# Altium schematic sheet 02_Top_Level.SchDoc
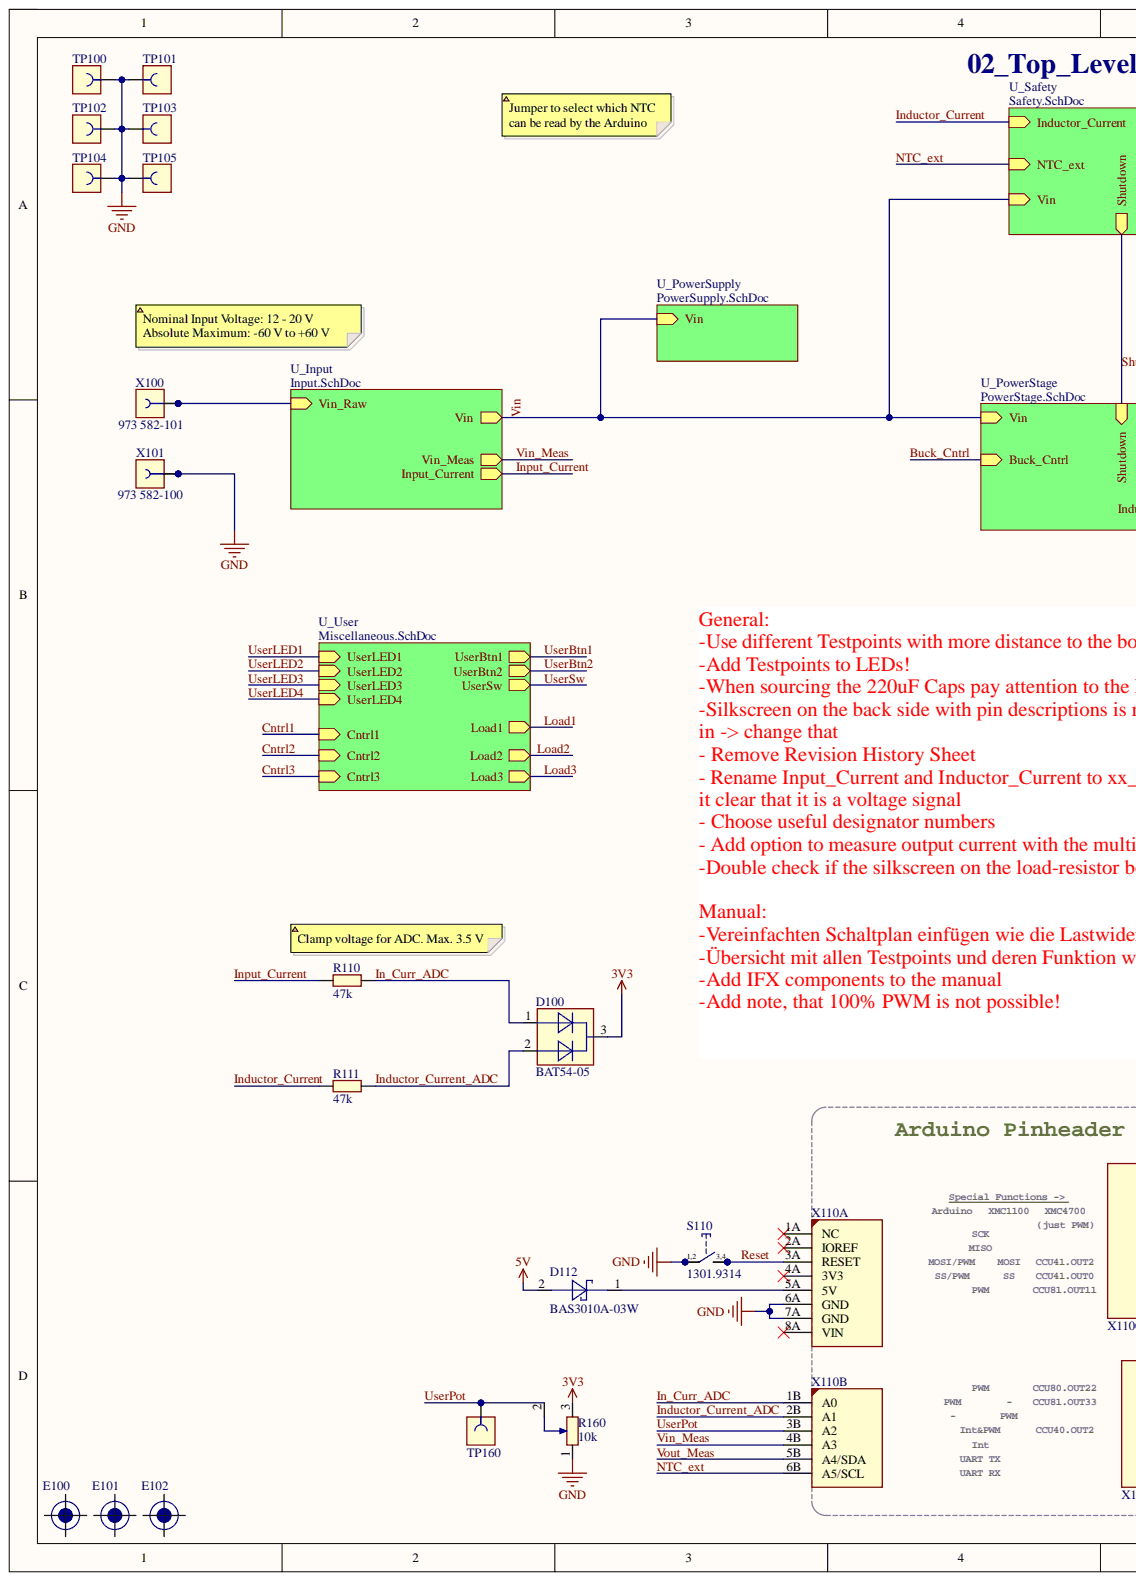
[diagram p0: 02_Top_Level.SchDoc, left]
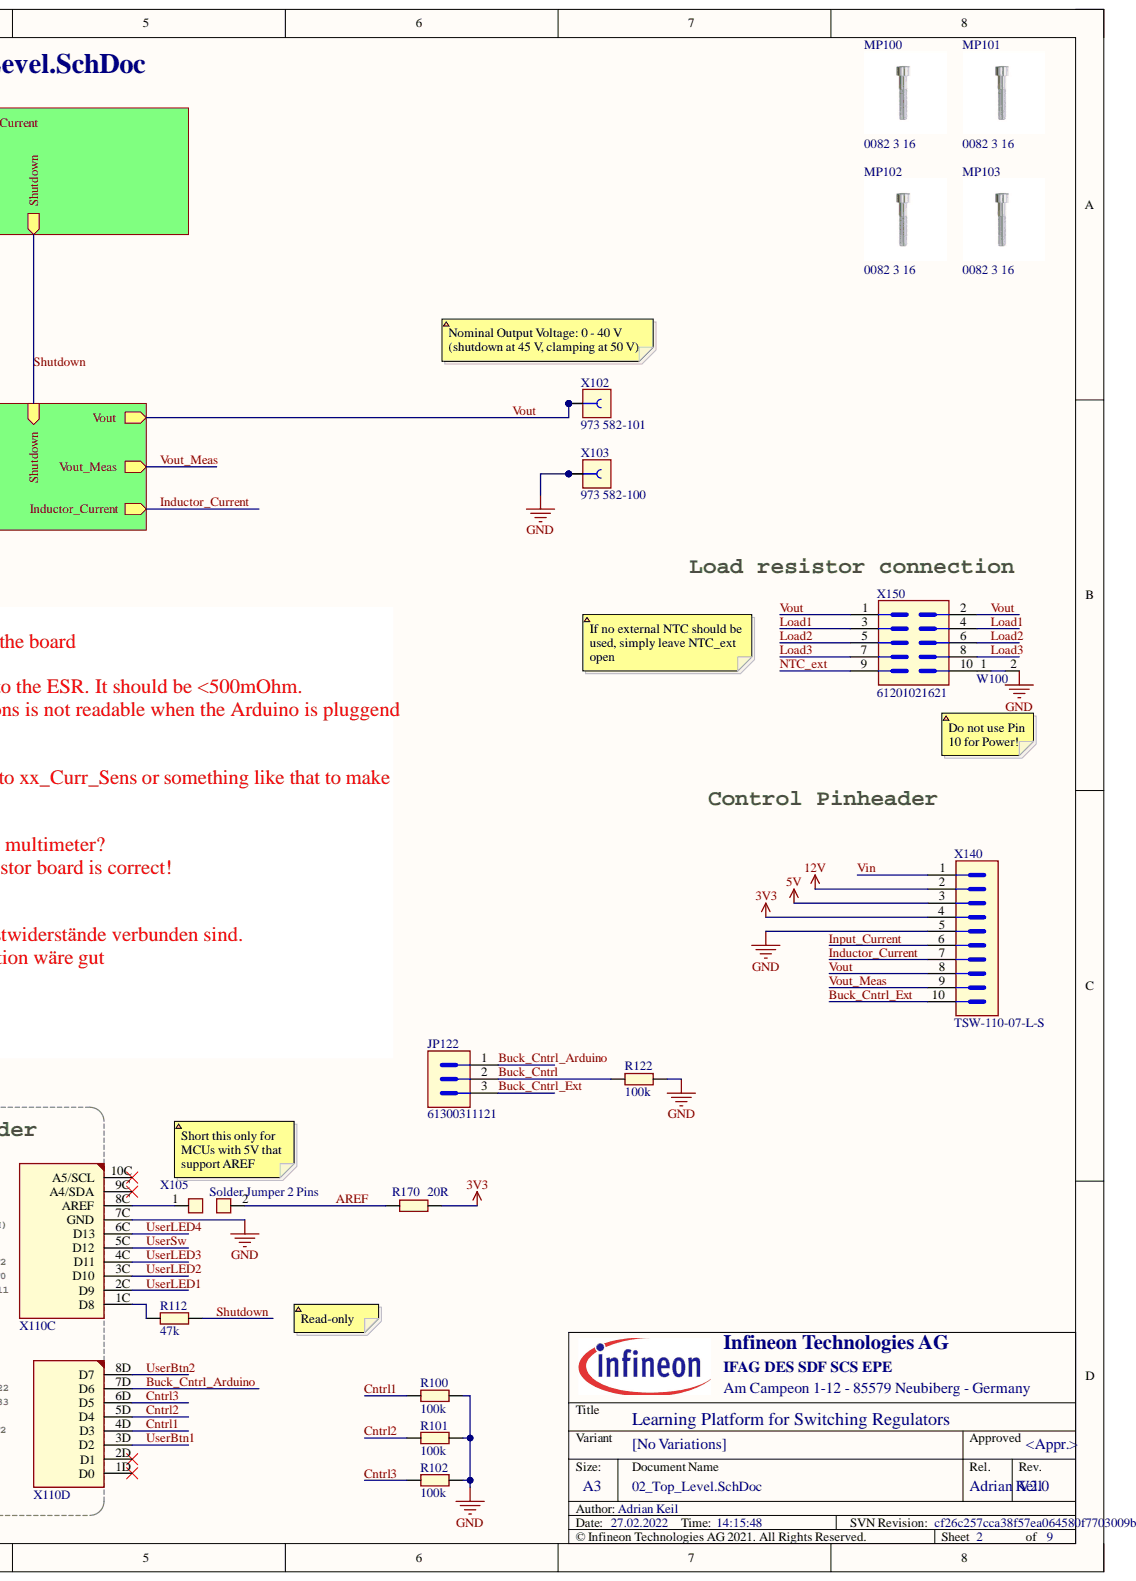
[diagram p1: 02_Top_Level.SchDoc, right]

## Parts
D100  BAT54-05  [SOT95P240X110-3N-1-V]
D112  BAS3010A-03W  [SOD2513X110N]
E100  (no value)  [fiducial]  (no BOM)
E101  (no value)  [fiducial]  (no BOM)
E102  (no value)  [fiducial]  (no BOM)
JP122  61300311121  [CON-M-THT-61300311121]
MP100  0082 3 16  [SCREW_0082 3 16_NPTH]
MP101  0082 3 16  [SCREW_0082 3 16_NPTH]
MP102  0082 3 16  [SCREW_0082 3 16_NPTH]
MP103  0082 3 16  [SCREW_0082 3 16_NPTH]
R100  100k  [RESC1609X50N]
R101  100k  [RESC1609X50N]
R102  100k  [RESC1609X50N]
R110  47k  [RESC1609X50N]
R111  47k  [RESC1609X50N]
R112  47k  [RESC1609X50N]
R122  100k  [RESC1609X50N]
R160  10k  [POT-SMD-3361P]
R170  20R  [RESC1609X50N]
S110  1301.9314  [SW-SMD-1301.9314]
TP100  5011  [CON-THT-TP-5011]  (value not drawn)
TP101  5011  [CON-THT-TP-5011]  (value not drawn)
TP102  5011  [CON-THT-TP-5011]  (value not drawn)
TP103  5011  [CON-THT-TP-5011]  (value not drawn)
TP104  5011  [CON-THT-TP-5011]  (value not drawn)
TP105  5011  [CON-THT-TP-5011]  (value not drawn)
TP160  S1751-46R  [CON-SMD-TT-S1751-46]  (value not drawn)
W100  Net_Tie_2  [Net_Tie_Small_2P]  (value not drawn)
X100  973 582-101  [CON-THT-973 582- 101]
X101  973 582-100  [CON-THT-973 582- 100]
X102  973 582-101  [CON-THT-973 582- 101]
X103  973 582-100  [CON-THT-973 582- 100]
X105  Solder Jumper 2 Pins  [SOLDER_BRIDGE_0.7X1.299PAD]
X110  Arduino-Uno  [Arduino-Uno]  (value not drawn)  (parts A,B,C,D)
X140  TSW-110-07-L-S  [CON-M-THT-TSW-110-07-L-S]
X150  61201021621  [CON-M-THT-61201021621]

## Nets
3V3: D100.3 R160.3 R170.2 X140.4
5V: D112.2 X140.3
12V: X140.2
~{Cntrl1}: R100.2 X110.4D(D3)
~{Cntrl2}: R101.2 X110.5D(D4)
~{Cntrl3}: R102.2 X110.6D(D5)
~{Shutdown}: R112.1
AREF: R170.1 X105.2
Buck_Cntrl: JP122.2 R122.2
Buck_Cntrl_Arduino: JP122.1 X110.7D(D6)
Buck_Cntrl_Ext: JP122.3 X140.10
GND: R100.1 R101.1 R102.1 R122.1 R160.1 S110.1 S110.2 TP100.1 TP101.1 TP102.1 TP103.1 TP104.1 TP105.1 W100.2 X101.1 X101.2 X103.1 X103.2 X110.6A(GND) X110.7A(GND) X110.7C(GND) X140.5
In_Curr_ADC: D100.1 R110.1 X110.1B(A0)
Inductor_Current: R111.2 X140.7
Inductor_Current_ADC: D100.2 R111.1 X110.2B(A1)
Input_Current: R110.2 X140.6
Load1: X150.3 X150.4
Load2: X150.5 X150.6
Load3: X150.7 X150.8
NTC_ext: X110.6B(A5/SCL) X150.9
Reset: S110.3 S110.4 X110.3A(RESET)
UserBtn1: X110.3D(D2)
UserBtn2: X110.8D(D7)
UserLED1: X110.2C(D9)
UserLED2: X110.3C(D10)
UserLED3: X110.4C(D11)
UserLED4: X110.6C(D13)
UserPot: R160.2 TP160.1 X110.3B(A2)
UserSw: X110.5C(D12)
Vin: X140.1
Vin_Meas: X110.4B(A3)
Vout: X102.1 X102.2 X140.8 X150.1 X150.2
Vout_Meas: X110.5B(A4/SDA) X140.9
NetD112_1: D112.1 X110.5A(5V)
NetR112_2: R112.2 X110.1C(D8)
NetW100_1: W100.1 X150.10
NetX100_1: X100.1 X100.2
NetX105_1: X105.1 X110.8C(AREF)
NetX110_1A: X110.1A(NC)
NetX110_1D: X110.1D(D0)
NetX110_2A: X110.2A(IOREF)
NetX110_2D: X110.2D(D1)
NetX110_4A: X110.4A(3V3)
NetX110_8A: X110.8A(VIN)
NetX110_9C: X110.9C(A4/SDA)
NetX110_10C: X110.10C(A5/SCL)

## Library data of the parts
D100 = 430837: Silicon Schottky Diode, LS(nH) 1.8, VR 30V; Manufacturer: Infineon Technologies; Automotive Qualified: AEC Q101; Combined Parameters: Vf:800mV;If:200mA;Ur:30V;Ir:2uA;Pmax:230mW; ComponentStatus: Released; Database Table Name: TPartsAll; Legacy DeviceID: IFX01401370; PLMStatus: Active; QuickPick: Schottky Diode / PG-SOT23-3-11; Second Source allowed: Yes; Style: Dual; Symbol type: Dual Diode Symbol 3 Pins, 1 Anode, 2 Anode, 3 Cathode; Version Number: V01; Voltage DC (max): na; Application: Standard; Article Number: 430837; Component Kind: Standard; ComponentLink1Description: Vendor WebSite; ComponentLink1URL: https://www.infineon.com/; ComponentLink2Description: Datasheet; ComponentLink2URL: http://webnetprod.muc.infineon.com/PCBPortal_Prod/WorkFlows/AltiumComponent/StandardDocumentation?Versionid=430838; Legacy PartNumber: IFX01401370; Library Name: PCBLibraryData.SVNDbLib; Manufacturer Order Number: BAT54-05; Package Description: SOT,0.95mm pitch;3 pin,1.30mm W X 2.90mm L X 1.10mm H body; PackageReference: PG-SOT23-3-11; Part Type: Diode; ROHS Compliant: Y; Supplier 1: Farnell; Supplier 2: DigiKey; Supplier 3: Mouser; Supplier Part Number 1: 2725759; Supplier Part Number 2: BAT5405E6327HTSA1TR-ND; Supplier Part Number 3: 726-BAT5405E6327HTSA; Temperature max: 150; Temperature min: 0; Temperature Range: Military; Type: Schottky Diode; Value: BAT54-05
D112 = 420617: Medium Power AF Schottky Diode; Manufacturer: Infineon Technologies; Automotive Qualified: AEC Q101; Combined Parameters: Vf:410mV;If:1A;Ur:30V;Ir:200uA;Pmax:-; ComponentStatus: Released; Database Table Name: TPartsAll; Legacy DeviceID: IFX01400145; PLMStatus: Active; QuickPick: Schottky Diode / SOD323; Second Source allowed: Yes; Style: Single; Symbol Type: Standard Schottky Diode Symbol, 1 Cathode, 2 Anode; Version Number: V01; Voltage DC (max): na; Application: Standard; Article Number: 420617; Component Kind: Standard; ComponentLink1Description: Vendor WebSite; ComponentLink1URL: https://www.infineon.com/; ComponentLink2Description: Datasheet; ComponentLink2URL: http://webnetprod.muc.infineon.com/PCBPortal_Prod/WorkFlows/AltiumComponent/StandardDocumentation?Versionid=420618; Legacy PartNumber: IFX01400145; Library Name: PCBLibraryData.SVNDbLib; Manufacturer Order Number: BAS3010A-03W; Package Description: SOD323; PackageReference: SOD323; Part Type: Diode; ROHS Compliant: Y; Supplier 1: Farnell; Supplier 2: DigiKey; Supplier 3: Mouser; Supplier Part Number 2: BAS3010A03WE6327HTSA1TR-ND; Supplier Part Number 3: 726-BAS3010A-03WE6; Temperature max: 125; Temperature min: -65; Temperature Range: Military; Type: Schottky Diode; Value: BAS3010A-03W
E100-E102 = 416637: Fiducial Mark; Legacy PartNumber: MEC10000008; Manufacturer: Infineon Technologies; Alternate View: No; Combined Parameters: - - -x- -; ComponentStatus: Released; Database Table Name: TPartsAll; Legacy DeviceID: MEC_Fiducial_Mark; PLMStatus: Active; Second Source allowed: Yes; Symbol Type: Fiducial Marker For PCB; Version Number: V01; Voltage DC (max): na; Article Number: 416637; ComponentLink1Description: Vendor WebSite; ComponentLink1URL: https://www.infineon.com/; ComponentLink2Description: Datasheet; ComponentLink2URL: http://webnetprod.muc.infineon.com/PCBPortal_Prod/WorkFlows/AltiumComponent/StandardDocumentation?Versionid=416638; Library Name: PCBLibraryData.SVNDbLib; Multible Parts: No; Part Type: Mechanical; Supplier 1: Farnell; Supplier 2: DigiKey; Supplier 3: Mouser; Temperature max: 0; Temperature min: 0; Temperature Range: Military
JP122 = 633993: WR-PHD Pin Header; Manufacturer: Wurth Elektronik; Automotive Qualified: No; Combined Parameters: Board-to-Cable Header (male);3x1;Mounting:THT;Orient:0° (vertical);Shielded:No; ComponentStatus: Released; Database Table Name: TPartsAll; Legacy DeviceID: WE_61300311121; PLMStatus: Active; QuickPick: 0° (vertical) / Board-to-Cable; Second Source allowed: Yes; Style: Strip; Version Number: V01; Voltage DC (max): na; Article Number: 633993; Component Kind: Standard; ComponentLink1Description: Vendor WebSite; ComponentLink1URL: http://www.we-online.com; ComponentLink2Description: Datasheet; ComponentLink2URL: http://webnetprod.muc.infineon.com/PCBPortal_Prod/WorkFlows/AltiumComponent/StandardDocumentation?Versionid=633994; Library Name: PCBLibraryData.SVNDbLib; Manufacturer Order Number: 61300311121; Package Description: Connector, THT; 2.54mm pitch, 7.62 mm L X 2.54 mm X 8.89 mm H body; PackageReference: THT; Part Type: Connector; ROHS Compliant: Y; Supplier 1: Farnell; Supplier 2: DigiKey; Supplier 3: Mouser; Supplier Part Number 1: 2356154; Supplier Part Number 2: 732-5316-ND; Supplier Part Number 3: 710-61300311121; Temperature max: 105; Temperature min: -40; Temperature Range: Industrial; Type: Board-to-Cable; Value: 61300311121
MP100-MP103 = 455343: Cheese Head Screw With Hexagon Socket, DIN 912 (ISO 4762), M3, 16mm length; Legacy PartNumber: MEC10000167; Manufacturer: Wurth Elektronik; Alternate View: No; Combined Parameters: Steel Hexagonal 19x5.68 Screw; ComponentStatus: Released; Database Table Name: TPartsAll; Legacy DeviceID: MEC_0082 3 16; PLMStatus: Active; Second Source allowed: Yes; Style: Hexagonal; Symbol Type: Cheese-Head Screw, M2.5X5-DIN 912; Version Number: V01; Voltage DC (max): na; Article Number: 455343; Automotive Qualified: No; Component Kind: Standard; ComponentLink1Description: Vendor WebSite; ComponentLink1URL: http://www.we-online.com; ComponentLink2Description: Datasheet; ComponentLink2URL: http://webnetprod.muc.infineon.com/PCBPortal_Prod/WorkFlows/AltiumComponent/StandardDocumentation?Versionid=455344; Library Name: PCBLibraryData.SVNDbLib; Manufacturer Order Number: 0082 3 16; Multiple Parts: No; Package Description: M3 Cheese Head Screw X 5.68mm Head; PackageReference: THT; Part Type: Mechanical; QuickPick: Screw; ROHS Compliant: N; Supplier 1: Farnell; Supplier 2: DigiKey; Supplier 3: Mouser; Temperature max: 0; Temperature min: 0; Temperature Range: Military; Type: Screw; Value: 0082 3 16
R100-R102 R122 = 346742: RES / STD / 100k / 100mW / 1% / 100ppm/K / -55°C to 155°C / 0603 / SMD / -; Manufacturer: Vishay; Manufacturer Order Number: CRCW0603100KFK; Multiple Parts: No; Alternate View: Yes; Automotive Qualified: AEC Q200; Combined Parameters: TCR:100ppm/K;Tol.:-1%/+1%;Vdc:75V;Idc:-;P:100mW; ComponentStatus: Released; Database Table Name: TPartsAll; Legacy DeviceID: RES01801371; PLMStatus: Active; QuickPick: 100k / 1% / 0.1 / 0603; Style: Single; Symbol Type: Resistor; Single; Standard; 2 Pins; Version Number: V01; Voltage DC (max): 75V; Article Number: 346742; Component Kind: Standard; ComponentLink1Description: Vendor WebSite; ComponentLink1URL: http://www.vishay.com; ComponentLink2Description: Datasheet; ComponentLink2URL: http://webnetprod.muc.infineon.com/PCBPortal_Prod/WorkFlows/AltiumComponent/StandardDocumentation?Versionid=346743; Legacy PartNumber: RES01801371; Library Name: PCBLibraryData.SVNDbLib; PackageReference: 0603; Part Type: Resistor; ROHS Compliant: Y; Second Source allowed: Yes; Supplier 1: Farnell; Supplier 2: DigiKey; Supplier 3: Mouser; Supplier Part Number 1: 1469649; Technology: Thick Film; Temperature max: 155; Temperature min: -55; Temperature Range: Military; Tolerance Neg: 1%; Tolerance Pos: 1%; Type: Standard; Value: 100k
R110-R112 = 346552: RES / STD / 47k / 100mW / 1% / 100ppm/K / -55°C to 155°C / 0603 / SMD / -; Manufacturer: Vishay; Manufacturer Order Number: CRCW060347K0FK; Multiple Parts: No; Alternate View: Yes; Automotive Qualified: AEC Q200; Combined Parameters: TCR:100ppm/K;Tol.:-1%/+1%;Vdc:75V;Idc:-;P:100mW; ComponentStatus: Released; Database Table Name: TPartsAll; Legacy DeviceID: RES01801333; PLMStatus: Active; QuickPick: 47k / 1% / 0.1 / 0603; Style: Single; Symbol Type: Resistor; Single; Standard; 2 Pins; Version Number: V01; Voltage DC (max): 75V; Article Number: 346552; Component Kind: Standard; ComponentLink1Description: Vendor WebSite; ComponentLink1URL: http://www.vishay.com; ComponentLink2Description: Datasheet; ComponentLink2URL: http://webnetprod.muc.infineon.com/PCBPortal_Prod/WorkFlows/AltiumComponent/StandardDocumentation?Versionid=346553; Legacy PartNumber: RES01801333; Library Name: PCBLibraryData.SVNDbLib; PackageReference: 0603; Part Type: Resistor; ROHS Compliant: Y; Second Source allowed: Yes; Supplier 1: Farnell; Supplier 2: DigiKey; Supplier 3: Mouser; Supplier Part Number 2: 541-47.0KHCT-ND; Technology: Thick Film; Temperature max: 155; Temperature min: -55; Temperature Range: Military; Tolerance Neg: 1%; Tolerance Pos: 1%; Type: Standard; Value: 47k
R160 = 630020: RES / VAR / 10k / 500mW / 10% / 100ppm/K / -55°C to 125°C /  3 pin, 7.04 mm L X 6.71 mm W X 5.84 mm H Body / SMD / -; Manufacturer: Bourns; Manufacturer Order Number: 3361P-1-103GLF; Alternate View: Yes; Automotive Qualified: No; Combined Parameters: TCR:100ppm/K;Tol.:-10%/+10%;Vdc:-;Idc:-;P:500mW; ComponentStatus: Released; Database Table Name: TPartsAll; PLMStatus: Active; QuickPick: 10k / 10% / 0.5 / SMD; Style: Single; Symbol Type: Resistor; Single; Variable; 3 Pins; Version Number: V01; Application: Standard; Article Number: 630020; Component Kind: Standard; ComponentLink1Description: Vendor WebSite; ComponentLink1URL: http://www.bourns.com; ComponentLink2Description: Datasheet; ComponentLink2URL: http://webnetprod.muc.infineon.com/PCBPortal_Prod/WorkFlows/AltiumComponent/StandardDocumentation?Versionid=630021; Library Name: PCBLibraryData.SVNDbLib; Multiple Parts: No; Package Description: Potentiometer, SMD; 3 pin, 7.04 mm L X 6.71 mm W X 5.84 mm H Body; PackageReference: SMD; Part Type: Resistor; ROHS Compliant: Y; Second Source allowed: Yes; Supplier 1: Farnell; Supplier 2: DigiKey; Supplier 3: Mouser; Supplier Part Number 1: 1696060; Supplier Part Number 2: 3361P-103GLFCT-ND; Supplier Part Number 3: 652-3361P-1-103GLF; Temperature max: 125; Temperature min: -55; Temperature Range: Military; Tolerance Neg: 10%; Tolerance Pos: 10%; Type: Variable; Value: 10k
R170 = 344622: RES / STD / 20R / 100mW / 1% / 100ppm/K / -55°C to 155°C / 0603 / SMD / -; Manufacturer: Vishay; Manufacturer Order Number: CRCW060320R0FK; Multiple Parts: No; Alternate View: Yes; Automotive Qualified: AEC Q200; Combined Parameters: TCR:100ppm/K;Tol.:-1%/+1%;Vdc:75V;Idc:-;P:100mW; ComponentStatus: Released; Database Table Name: TPartsAll; Legacy DeviceID: RES01800947; PLMStatus: Active; QuickPick: 20R / 1% / 0.1 / 0603; Style: Single; Symbol Type: Resistor; Single; Standard; 2 Pins; Version Number: V01; Voltage DC (max): 75V; Article Number: 344622; Component Kind: Standard; ComponentLink1Description: Vendor WebSite; ComponentLink1URL: http://www.vishay.com; ComponentLink2Description: Datasheet; ComponentLink2URL: http://webnetprod.muc.infineon.com/PCBPortal_Prod/WorkFlows/AltiumComponent/StandardDocumentation?Versionid=344623; Legacy PartNumber: RES01800947; Library Name: PCBLibraryData.SVNDbLib; PackageReference: 0603; Part Type: Resistor; ROHS Compliant: Y; Second Source allowed: Yes; Supplier 1: Farnell; Supplier 2: DigiKey; Supplier 3: Mouser; Technology: Thick Film; Temperature max: 155; Temperature min: -55; Temperature Range: Military; Tolerance Neg: 1%; Tolerance Pos: 1%; Type: Standard; Value: 20R
S110 = 380147: Pushbutton Tactile Switch, Single Pole Single Throw, 4 Pins; Legacy PartNumber: CON01500305; Manufacturer: Schurter; ComponentStatus: Released; Database Table Name: TPartsAll; Legacy DeviceID: SCH_1301.9314; PLMStatus: Active; Second Source allowed: Yes; Symbol type: Tactile Switch, Spring Return, Single Pole Single Throw - Normally Open, 4 Pins; Version Number: V01; Voltage DC (max): na; Article Number: 380147; Component Kind: Standard; ComponentLink1Description: Vendor WebSite; ComponentLink1URL: http://www.schurter.com.sg/; ComponentLink2Description: Datasheet; ComponentLink2URL: http://webnetprod.muc.infineon.com/PCBPortal_Prod/WorkFlows/AltiumComponent/StandardDocumentation?Versionid=380148; Library Name: PCBLibraryData.SVNDbLib; Manufacturer Order Number: 1301.9314; Package Description: SMD Switch, 6.00mm L X 6.00mm W X 4.3mm H body; Part Type: Electro Mechanic; ROHS Compliant: Y; Supplier 1: Farnell; Supplier 2: DigiKey; Supplier 3: Mouser; Supplier Part Number 1: 1217763; Supplier Part Number 2: 1301.9314-ND; Supplier Part Number 3: 693-1301.9314; Temperature max: 70; Temperature min: -20; Temperature Range: Commercial; Value: 1301.9314
TP100-TP105 = 382410: PC Test Point-Multipurpose THT, Black; Legacy PartNumber: CON01500530; Manufacturer: Keystone Electronics Corp.; Alternate View: Yes; Automotive Qualified: No; Combined Parameters: Board-to-Cable None (onboard);1x1;Mounting:THT;Orient:0° (vertical);Shielded:No; ComponentStatus: Released; Database Table Name: TPartsAll; Legacy DeviceID: KEY_5011; PLMStatus: Active; QuickPick: 0° (vertical) / Board-to-Cable; Style: Not Applicable; Symbol Type: Connector, 1 Lead, Female; Version Number: V01; Voltage DC (max): na; Application: Test; Article Number: 382410; Component Kind: Standard; ComponentLink1Description: Vendor WebSite; ComponentLink1URL: http://www.keyelco.com/; ComponentLink2Description: Datasheet; ComponentLink2URL: http://webnetprod.muc.infineon.com/PCBPortal_Prod/WorkFlows/AltiumComponent/StandardDocumentation?Versionid=382411; Library Name: PCBLibraryData.SVNDbLib; Manufacturer Order Number: 5011; Multiple Parts: No; Package Description: Test Point, 1.78 mm Lead Width, Round, 3.17 mm Dia X 8.28 mm H body; Part Type: Connector; ROHS Compliant: Y; Second Source allowed: Yes; Supplier 1: Farnell; Supplier 2: DigiKey; Supplier 3: Mouser; Supplier Part Number 1: 2431148; Supplier Part Number 2: 36-5011-ND; Supplier Part Number 3: 534-5011; Temperature max: 0; Temperature min: 0; Temperature Range: Military; Type: Board-to-Cable; Value: 5011
TP160 = 387486: Test Terminal, Surface Mount (Tape and Reeled Components); Legacy PartNumber: CON01501015; Manufacturer: Harwin; Alternate View: Yes; Automotive Qualified: No; Combined Parameters: Board-to-Cable None (onboard);1x1;Mounting:SMT;Orient:None (onboard);Shielded:No; ComponentStatus: Released; Database Table Name: TPartsAll; Legacy DeviceID: HAR_S1751-46R; PLMStatus: Active; QuickPick: None (onboard) / Board-to-Cable; Style: Not Applicable; Symbol Type: Connector, 1 Lead, Female; Version Number: V01; Voltage DC (max): na; Application: Test; Article Number: 387486; Component Kind: Standard; ComponentLink1Description: Vendor WebSite; ComponentLink1URL: http://www.harwin.com/; ComponentLink2Description: Datasheet; ComponentLink2URL: http://webnetprod.muc.infineon.com/PCBPortal_Prod/WorkFlows/AltiumComponent/StandardDocumentation?Versionid=387487; Library Name: PCBLibraryData.SVNDbLib; Manufacturer Order Number: S1751-46R; Multiple Parts: No; Package Description: Test Terminal, SMD, 3.25mm L X 1.65mm W X 2.15mm H body; Part Type: Connector; ROHS Compliant: Y; Second Source allowed: Yes; Supplier 1: Farnell; Supplier 2: DigiKey; Supplier 3: Mouser; Supplier Part Number 1: 2411184; Supplier Part Number 2: 952-1478-2-ND; Supplier Part Number 3: 855-S1751-46R; Temperature max: 0; Temperature min: 0; Temperature Range: Military; Type: Board-to-Cable; Value: S1751-46R
W100 = 453053: SMD Net Tie for two Nets; Legacy PartNumber: CON01501379; Manufacturer: Infineon Technologies; Manufacturer Order Number: Net Tie_small_2p; Alternate View: Yes; Combined Parameters: - onboard connector;-x-;Mounting:SMT;Orient:-;Shielded:-; ComponentStatus: Released; Database Table Name: TPartsAll; Legacy DeviceID: CON_Net Tie_small_2p; PLMStatus: Active; Value: Net Tie_small_2p; Version Number: V01; Voltage DC (max): na; Article Number: 453053; Component Kind: Net Tie; ComponentLink1Description: Vendor WebSite; ComponentLink1URL: https://www.infineon.com/; ComponentLink2Description: Datasheet; Library Name: PCBLibraryData.SVNDbLib; Package Description: SMD Net Tie 0.2mm x 0.6mm; PackageReference: SMD; Part Type: Connector; Second Source allowed: Yes; Supplier 1: Farnell; Supplier 2: DigiKey; Supplier 3: Mouser; Symbol Type: Net Tie for two Nets; Temperature max: 0; Temperature min: 0; Temperature Range: Military
X100 X102 = 377341: Banana Socket, Red, 17.5mm Pitch; Legacy PartNumber: CON01500041; Manufacturer: Hirschmann Test & Measurement; Manufacturer Component Status: Active; Combined Parameters: Board-to-Cable Socket (female);2x1;Mounting:THT;Orient:90° (right angle);Shielded:No; ComponentStatus: Released; Database Table Name: TPartsAll; Legacy DeviceID: HTM_973 582- 101; QuickPick: 90° (right angle) / Board-to-Cable; Second Source allowed: Yes; Symbol Type: Banana Socket 2 Pins; Version Number: V01; Voltage DC (max): na; Article Number: 377341; Component Kind: Standard; ComponentLink1Description: Vendor WebSite; ComponentLink1URL: http://www.sks-kontakt.de/; ComponentLink2Description: Datasheet; ComponentLink2URL: http://webnetprod.muc.infineon.com/PCBPortal_Prod/WorkFlows/AltiumComponent/StandardDocumentation?Versionid=377342; Library Name: PCBLibraryData.SVNDbLib; Manufacturer Order Number: 973 582-101; Package Description: Banana Jack, 2 pins, 17.5mm Pitch, 21mm L X 7.5mm W X 10.5mm H body; Part Type: Connector; PLMStatus: Active; ROHS Compliant: N; Style: Banana; Supplier 1: Farnell; Supplier 2: DigiKey; Supplier 3: Mouser; Supplier Part Number 1: 497332; Supplier Part Number 3: 845-973582101; Temperature max: 85; Temperature min: -25; Temperature Range: Military; Type: Board-to-Cable; Value: 973 582-101
X101 X103 = 377401: Banana Socket, black, 17.5mm Pitch; Legacy PartNumber: CON01500045; Manufacturer: Hirschmann Test & Measurement; Manufacturer Component Status: Active; Automotive Qualified: No; Combined Parameters: Board-to-Cable Socket (female);-x-;Mounting:THT;Orient:90° (right angle);Shielded:No; ComponentStatus: Released; Database Table Name: TPartsAll; Legacy DeviceID: HTM_973 582- 100; QuickPick: 90° (right angle) / Board-to-Cable; Second Source allowed: Yes; Symbol Type: Banana Socket 2 Pins; Version Number: V01; Voltage DC (max): na; Article Number: 377401; Component Kind: Standard; ComponentLink1Description: Vendor WebSite; ComponentLink1URL: http://www.sks-kontakt.de/; ComponentLink2Description: Datasheet; ComponentLink2URL: http://webnetprod.muc.infineon.com/PCBPortal_Prod/WorkFlows/AltiumComponent/StandardDocumentation?Versionid=377402; Library Name: PCBLibraryData.SVNDbLib; Manufacturer Order Number: 973 582-100; Package Description: Banana Jack, 2 pins, 17.5mm Pitch, 21mm L X 7.5mm W X 10.5mm H body; Part Type: Connector; PLMStatus: Active; ROHS Compliant: N; Style: Banana; Supplier 1: Farnell; Supplier 2: DigiKey; Supplier 3: Mouser; Supplier Part Number 1: 497344; Supplier Part Number 3: 845-973582100; Temperature max: 85; Temperature min: -25; Temperature Range: Military; Type: Board-to-Cable; Value: 973 582-100
X105 = 433601: Legacy PartNumber: CON01500959; Manufacturer: Infineon Technologies; Alternate View: Yes; Automotive Qualified: No; Combined Parameters: - None (onboard);2x1;Mounting:SMT;Orient:None (onboard);Shielded:No; ComponentStatus: Released; Database Table Name: TPartsAll; Legacy DeviceID: IFX_Solder Jumper 2 Pins; PLMStatus: Active; QuickPick: None (onboard); Style: Not Applicable; Version Number: V01; Voltage DC (max): na; Article Number: 433601; Component Kind: Standard; ComponentLink1Description: Vendor WebSite; ComponentLink1URL: https://www.infineon.com/; ComponentLink2Description: Datasheet; Description: Solder Jumper 2 Pins; Library Name: PCBLibraryData.SVNDbLib; Manufacturer Order Number: Solder Jumper 2 Pins; Multiple Parts: No; Package Description: Solder Bridge 2 Pins, 0.7X1.299mm Pad, 1.6mm L X 1.2mm W body; Part Type: Connector; ROHS Compliant: N; Second Source allowed: Yes; Supplier 1: Farnell; Supplier 2: DigiKey; Supplier 3: Mouser; Symbol Type: Solder Jumper 2 Pins; Temperature max: 0; Temperature min: 0; Temperature Range: Military; Value: Solder Jumper 2 Pins
X110 = 644888: Arduino Uno is a microcontroller board based on the ATmega328P; Manufacturer: Infineon Technologies; Alternate View: Yes; Automotive Qualified: No; Combined Parameters: Board-to-Board Socket (female);-x-;Mounting:THT;Orient:0° (vertical);Shielded:-; ComponentStatus: Released; Database Table Name: TPartsAll; Legacy DeviceID: Infineon_Arduino-Uno; PLMStatus: Active; QuickPick: 0° (vertical) / Board-to-Board; Version Number: V01; Voltage DC (max): na; Article Number: 644888; Component Kind: Standard; ComponentLink1Description: Vendor WebSite; ComponentLink1URL: https://www.infineon.com/; ComponentLink2Description: Datasheet; Library Name: PCBLibraryData.SVNDbLib; Manufacturer Order Number: Arduino-Uno; Multiple Parts: Yes; Package Description: Arduino-Uno, THT, 32 Pin, 68.58 mm L X 53.34 mm W X 8.64 mm H body; PackageReference: THT; Part Type: Connector; ROHS Compliant: N; Second Source allowed: Yes; Supplier 1: Farnell; Supplier 2: DigiKey; Supplier 3: Mouser; Symbol Type: Arduino Uno is a microcontroller board based on the ATmega328P; Temperature max: 85; Temperature min: -40; Temperature Range: Industrial; Type: Board-to-Board; Value: Arduino-Uno
X140 = 382944: Through hole .025 SQ Post Header, 2.54mm pitch, 10 pin, vertical, single row; Legacy PartNumber: CON01500578; Manufacturer: Samtec; Automotive Qualified: No; Combined Parameters: Board-to-Board Header (male);10x1;Mounting:THT;Orient:0° (vertical);Shielded:No; ComponentStatus: Released; Database Table Name: TPartsAll; Legacy DeviceID: SAM_TSW-110-07-L-S; PLMStatus: Active; QuickPick: 0° (vertical) / Board-to-Board; Second Source allowed: Yes; Style: Strip; Symbol Type: Header 10 pins; Version Number: V01; Voltage DC (max): na; Article Number: 382944; Component Kind: Standard; ComponentLink1Description: Vendor WebSite; ComponentLink1URL: http://www.samtec.com/; ComponentLink2Description: Datasheet; ComponentLink2URL: http://webnetprod.muc.infineon.com/PCBPortal_Prod/WorkFlows/AltiumComponent/StandardDocumentation?Versionid=382945; Library Name: PCBLibraryData.SVNDbLib; Manufacturer Order Number: TSW-110-07-L-S; Package Description: Header,vertical,2.54mm pitch;0.64mm lead width,10 pins,1 row,10 pins per row,25.40mm L X 2.54mm W X 8.636mm H body; Part Type: Connector; ROHS Compliant: Y; Supplier 1: Farnell; Supplier 2: DigiKey; Supplier 3: Mouser; Supplier Part Number 1: 2035612; Supplier Part Number 2: SAM1031-10-ND; Temperature max: 125; Temperature min: -55; Temperature Range: Military; Type: Board-to-Board; Value: TSW-110-07-L-S
X150 = 638630: WR-BHD Male Box Header, 2.54mm pitch, 10 pin, Vertical, Double row; Manufacturer: Wurth Elektronik; Automotive Qualified: No; Combined Parameters: Board-to-Board/Cable Header (male);5x2;Mounting:THT;Orient:0° (vertical);Shielded:No; ComponentStatus: Released; Database Table Name: TPartsAll; Legacy DeviceID: WE_61201021621; PLMStatus: Active; QuickPick: 0° (vertical) / Board-to-Board/Cable; Second Source allowed: Yes; Style: Strip; Version Number: V01; Voltage DC (max): na; Article Number: 638630; Component Kind: Standard; ComponentLink1Description: Vendor WebSite; ComponentLink1URL: http://www.we-online.com; ComponentLink2Description: Datasheet; ComponentLink2URL: http://webnetprod.muc.infineon.com/PCBPortal_Prod/WorkFlows/AltiumComponent/StandardDocumentation?Versionid=638631; Library Name: PCBLibraryData.SVNDbLib; Manufacturer Order Number: 61201021621; Package Description: Connector, THT, 2.54mm Pitch; 10 Pins, 9.10 mm L X 20.36 mm W X 9.25 mm H body; PackageReference: THT; Part Type: Connector; ROHS Compliant: Y; Supplier 1: Farnell; Supplier 2: DigiKey; Supplier 3: Mouser; Supplier Part Number 1: 1642019; Supplier Part Number 2: 732-2094-ND; Supplier Part Number 3: 710-61201021621; Temperature max: 105; Temperature min: -40; Temperature Range: Industrial; Type: Board-to-Board/Cable; Value: 61201021621
